# Altium schematic sheet PS_BANKS.SchDoc
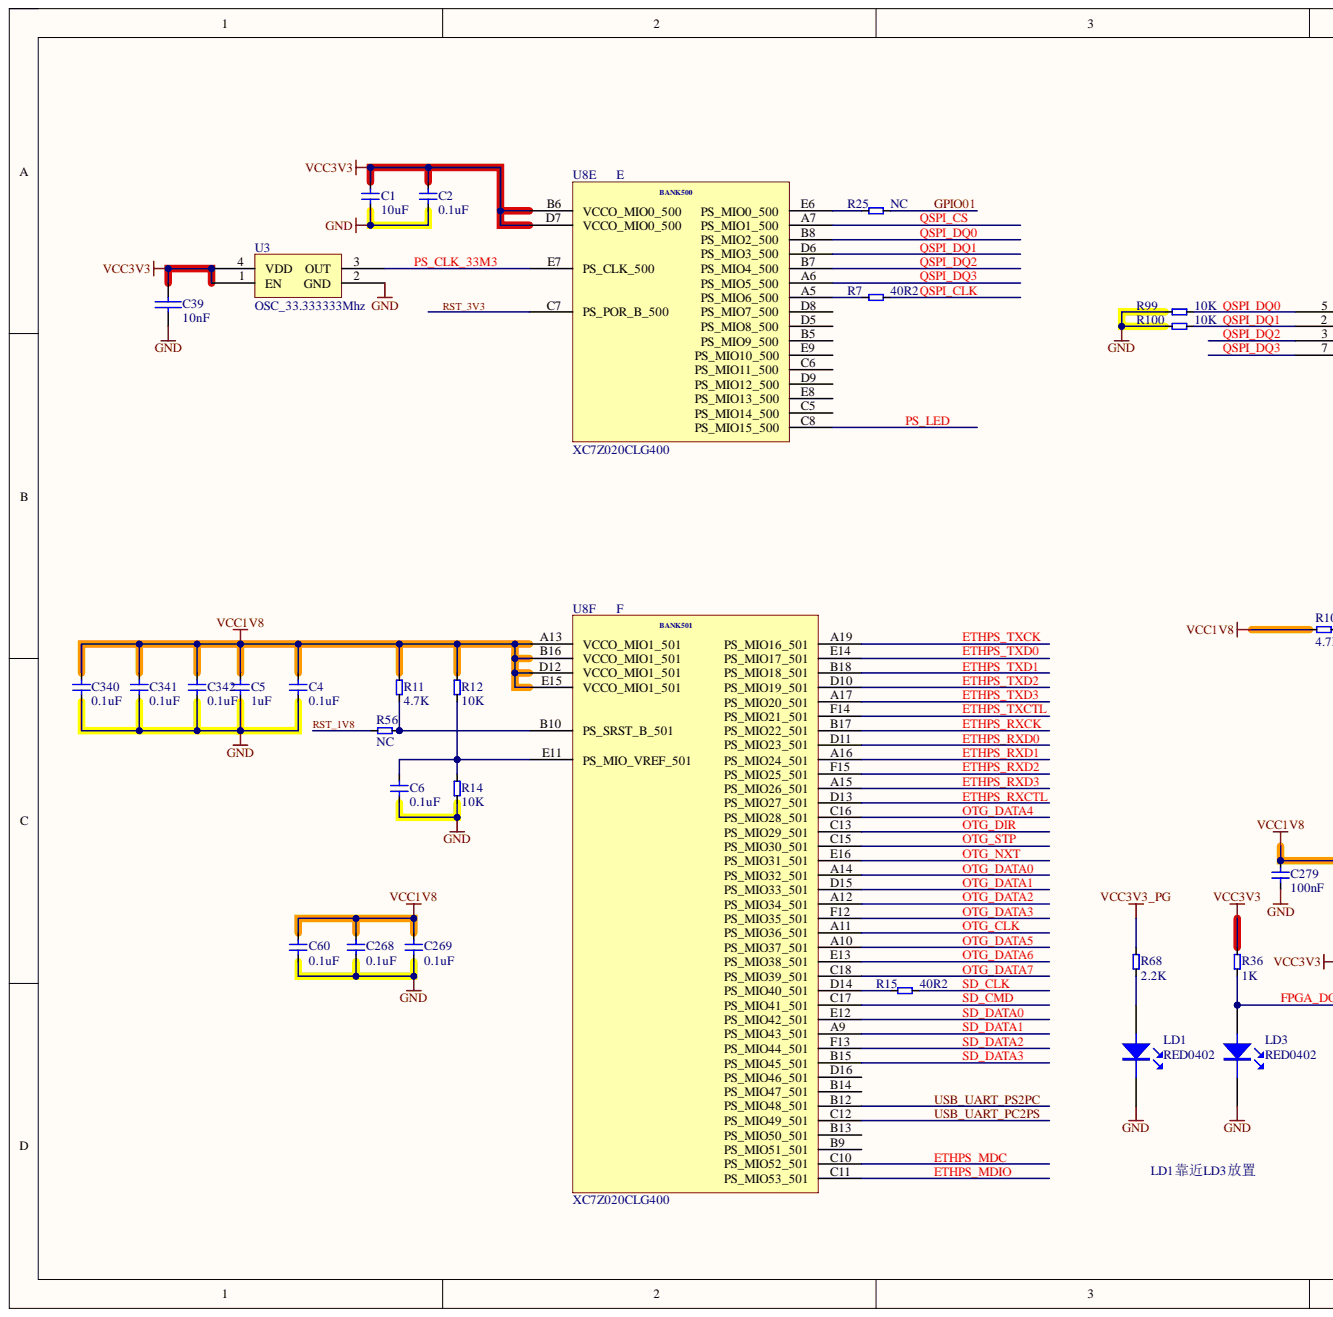
[diagram p0: PS_BANKS.SchDoc, left]
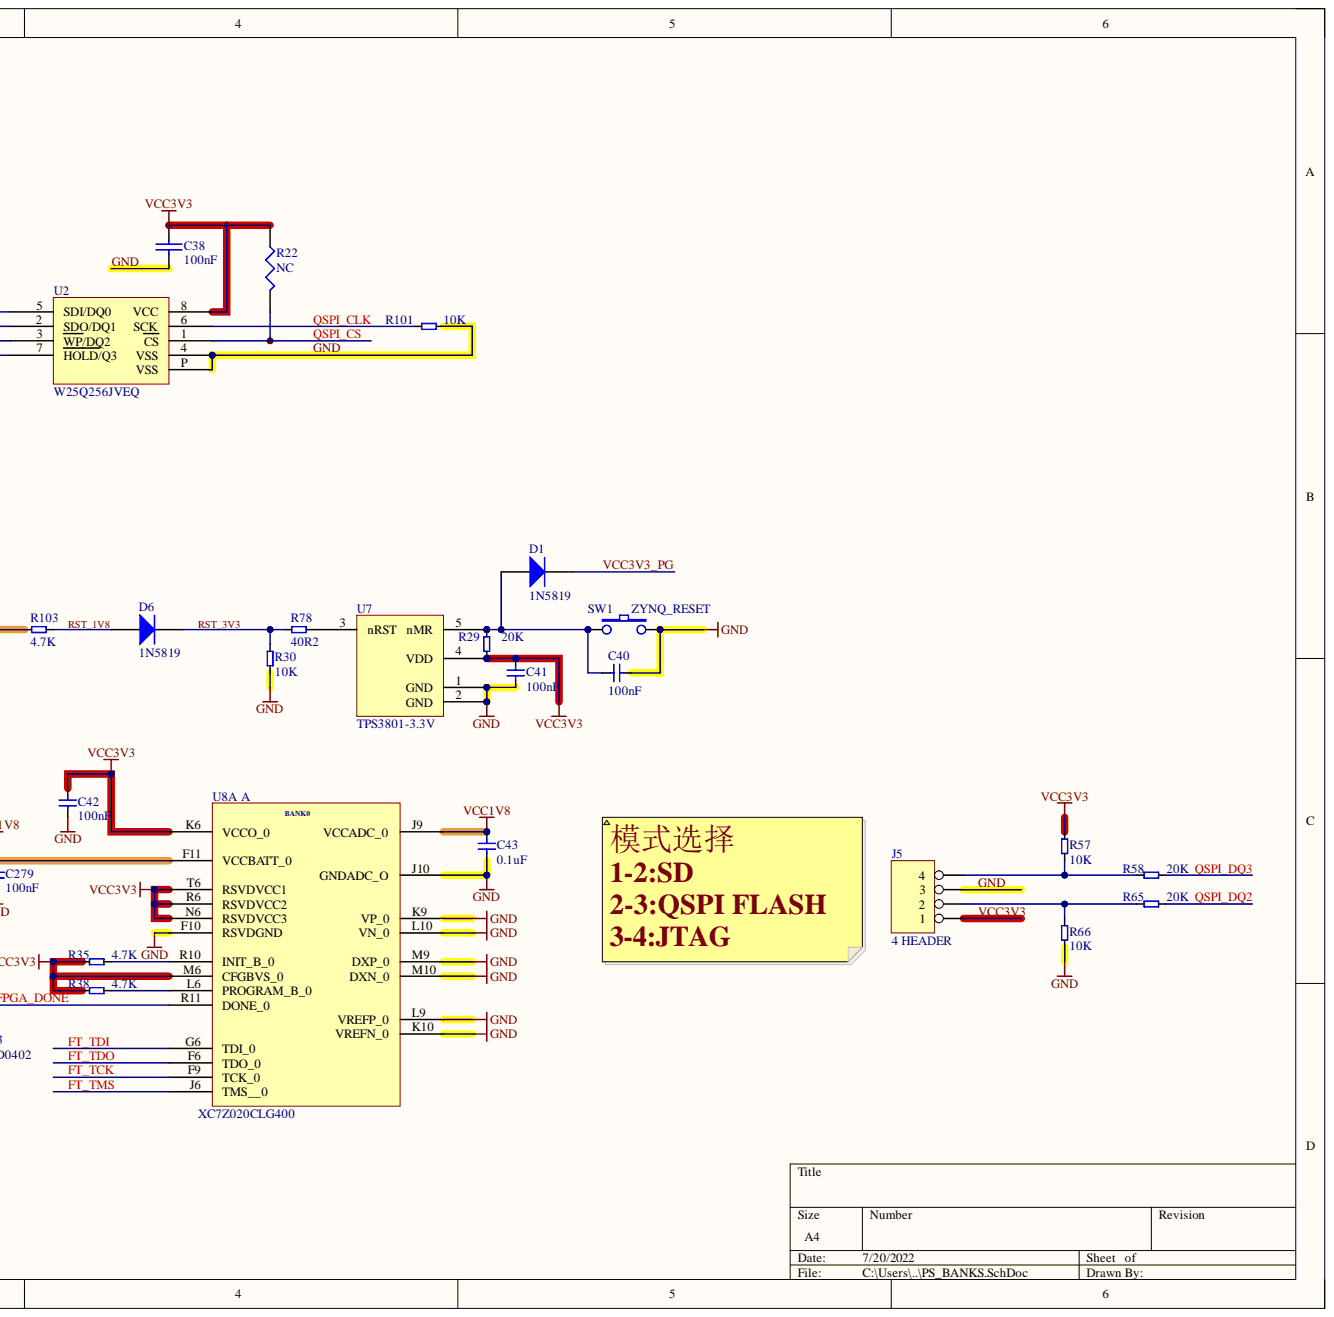
[diagram p1: PS_BANKS.SchDoc, right]

## Parts
C1  10uF  [C0603]
C2  0.1uF  [C0201]
C4  0.1uF  [C0201]
C5  1uF  [C0402]
C6  0.1uF  [C0201]
C38  100nF  [C0201]
C39  10nF  [CAP0402]
C40  100nF  [C0201]
C41  100nF  [C0201]
C42  100nF  [C0201]
C43  0.1uF  [C0201]
C60  0.1uF  [C0201]
C268  0.1uF  [C0201]
C269  0.1uF  [C0201]
C279  100nF  [C0201]
C340  0.1uF  [C0201]
C341  0.1uF  [C0201]
C342  0.1uF  [C0201]
D1  1N5819  [SOD-523_12]
D6  1N5819  [SOD-523_12]
J5  4 HEADER  [SIP4]
LD1  RED0402  [LED0402]
LD3  RED0402  [LED0402]
R7  40R2  [R0201]
R11  4.7K  [R0201]
R12  10K  [R0201]
R14  10K  [R0201]
R15  40R2  [R0201]
R22  NC  [R0201]
R25  NC  [R0201]
R29  20K  [R0201]
R30  10K  [R0201]
R35  4.7K  [R0201]
R36  1K  [R0201]
R38  4.7K  [R0201]
R56  NC  [R0201]
R57  10K  [R0201]
R58  20K  [R0201]
R65  20K  [R0201]
R66  10K  [R0201]
R68  2.2K  [R0201]
R78  40R2  [R0201]
R99  10K  [R0201]
R100  10K  [R0201]
R101  10K  [R0201]
R103  4.7K  [R0201]
SW1  ZYNQ_RESET  [EDGEKEY]
U2  W25Q256JVEQ  [MLP8]
U3  OSC_33.333333Mhz  [OSC_3225]
U7  TPS3801-3.3V  [SC-70-5]
U8  XC7Z020CLG400  [MY_CLG400]  (parts A,E,F)

## Nets
VCC1V8: C4.1 C5.1 C43.1 C60.2 C268.2 C269.2 C279.1 C340.1 C341.1 C342.1 R11.2 R12.2 R103.1 U8.A13(VCCO_MIO1_501) U8.B16(VCCO_MIO1_501) U8.D12(VCCO_MIO1_501) U8.E15(VCCO_MIO1_501) U8.F11(VCCBATT_0) U8.J9(VCCADC_0)
ETHPS_MDC: U8.C10(PS_MIO52_501)
ETHPS_MDIO: U8.C11(PS_MIO53_501)
ETHPS_RXCK: U8.B17(PS_MIO22_501)
ETHPS_RXCTL: U8.D13(PS_MIO27_501)
ETHPS_RXD0: U8.D11(PS_MIO23_501)
ETHPS_RXD1: U8.A16(PS_MIO24_501)
ETHPS_RXD2: U8.F15(PS_MIO25_501)
ETHPS_RXD3: U8.A15(PS_MIO26_501)
ETHPS_TXCK: U8.A19(PS_MIO16_501)
ETHPS_TXCTL: U8.F14(PS_MIO21_501)
ETHPS_TXD0: U8.E14(PS_MIO17_501)
ETHPS_TXD1: U8.B18(PS_MIO18_501)
ETHPS_TXD2: U8.D10(PS_MIO19_501)
ETHPS_TXD3: U8.A17(PS_MIO20_501)
FPGA_DONE: LD3.2 R36.1 U8.R11(DONE_0)
FT_TCK: U8.F9(TCK_0)
FT_TDI: U8.G6(TDI_0)
FT_TDO: U8.F6(TDO_0)
FT_TMS: U8.J6(TMS__0)
GND: C1.2 C2.2 C4.2 C5.2 C6.2 C38.2 C39.2 C40.2 C41.2 C42.2 C43.2 C60.1 C268.1 C269.1 C279.2 C340.2 C341.2 C342.2 J5.3 LD1.1 LD3.1 R14.1 R30.2 R66.1 R99.1 R100.1 R101.2 SW1.2 U2.4(VSS) U2.P(VSS) U3.2(GND) U7.1(GND) U7.2(GND) U8.F10(RSVDGND) U8.J10(GNDADC_O) U8.K9(VP_0) U8.K10(VREFN_0) U8.L9(VREFP_0) U8.L10(VN_0) U8.M9(DXP_0) U8.M10(DXN_0)
GPIO01: R25.2
OTG_CLK: U8.A11(PS_MIO36_501)
OTG_DATA0: U8.A14(PS_MIO32_501)
OTG_DATA1: U8.D15(PS_MIO33_501)
OTG_DATA2: U8.A12(PS_MIO34_501)
OTG_DATA3: U8.F12(PS_MIO35_501)
OTG_DATA4: U8.C16(PS_MIO28_501)
OTG_DATA5: U8.A10(PS_MIO37_501)
OTG_DATA6: U8.E13(PS_MIO38_501)
OTG_DATA7: U8.C18(PS_MIO39_501)
OTG_DIR: U8.C13(PS_MIO29_501)
OTG_NXT: U8.E16(PS_MIO31_501)
OTG_STP: U8.C15(PS_MIO30_501)
PS_CLK_33M3: U3.3(OUT) U8.E7(PS_CLK_500)
PS_LED: U8.C8(PS_MIO15_500)
QSPI_CLK: R7.2 R101.1 U2.6(SCK)
QSPI_CS: R22.2 U2.1(CS) U8.A7(PS_MIO1_500)
QSPI_DQ0: R99.2 U2.5(SDI/DQ0) U8.B8(PS_MIO2_500)
QSPI_DQ1: R100.2 U2.2(SDO/DQ1) U8.D6(PS_MIO3_500)
QSPI_DQ2: R65.2 U2.3(WP/DQ2) U8.B7(PS_MIO4_500)
QSPI_DQ3: R58.2 U2.7(HOLD/Q3) U8.A6(PS_MIO5_500)
RST_1V8: D6.1 R56.2 R103.2
RST_3V3: D6.2 R30.1 R78.1 U8.C7(PS_POR_B_500)
SD_CLK: R15.1
SD_CMD: U8.C17(PS_MIO41_501)
SD_DATA0: U8.E12(PS_MIO42_501)
SD_DATA1: U8.A9(PS_MIO43_501)
SD_DATA2: U8.F13(PS_MIO44_501)
SD_DATA3: U8.B15(PS_MIO45_501)
USB_UART_PC2PS: U8.C12(PS_MIO49_501)
USB_UART_PS2PC: U8.B12(PS_MIO48_501)
VCC3V3: C1.1 C2.1 C38.1 C39.1 C41.1 C42.1 J5.1 R22.1 R29.2 R35.1 R36.2 R38.1 R57.2 U2.8(VCC) U3.1(EN) U3.4(VDD) U7.4(VDD) U8.B6(VCCO_MIO0_500) U8.D7(VCCO_MIO0_500) U8.K6(VCCO_0) U8.M6(CFGBVS_0) U8.N6(RSVDVCC3) U8.R6(RSVDVCC2) U8.T6(RSVDVCC1)
VCC3V3_PG: D1.2 R68.2
NetC6_1: C6.1 R12.1 R14.2 U8.E11(PS_MIO_VREF_501)
NetC40_1: C40.1 D1.1 R29.1 SW1.1 U7.5(nMR)
NetJ5_2: J5.2 R65.1 R66.2
NetJ5_4: J5.4 R57.1 R58.1
NetLD1_2: LD1.2 R68.1
NetR7_1: R7.1 U8.A5(PS_MIO6_500)
NetR11_1: R11.1 R56.1 U8.B10(PS_SRST_B_501)
NetR15_2: R15.2 U8.D14(PS_MIO40_501)
NetR25_1: R25.1 U8.E6(PS_MIO0_500)
NetR35_2: R35.2 U8.R10(INIT_B_0)
NetR38_2: R38.2 U8.L6(PROGRAM_B_0)
NetR78_2: R78.2 U7.3(nRST)

Not connected: U8 B5(PS_MIO9_500) B9(PS_MIO51_501) B13(PS_MIO50_501) B14(PS_MIO47_501) C5(PS_MIO14_500) C6(PS_MIO11_500) D5(PS_MIO8_500) D8(PS_MIO7_500) D9(PS_MIO12_500) D16(PS_MIO46_501) E8(PS_MIO13_500) E9(PS_MIO10_500)

## Library data of the parts
C1 C2 C4-C6 C40-C43 C60 C268 C269 C279 C340-C342 = C: Capacitor; Component Kind: Standard; Library Name: 电容-SCH.LIB
C38 C39 = CAP: Capacitor; Component Kind: Standard
D1 D6 = SOD-123 B5819W  SL: Component Kind: Standard; ComponentLink1Description: 供应商链接; ComponentLink1URL: http://www.szlcsc.com/product/details_9093.html; Description: 1N5819; Library Name: Diode.SchLib; Package: SOD-123; Supplier: LC; SuppliersPartNumber: C8598
J5 = 4 HEADER: 4 Pin Header; Component Kind: Standard
LD1 LD3 = LED_12: Component Kind: Standard; Library Name: zc706_2_0.SCHLIB
R7 R11 R12 R14 R15 R25 R29 R30 R35 R36 R38 R56-R58 R65 R66 R68 R78 R99-R101 R103 = RES2: Component Kind: Standard; Library Name: 电阻-SCH.LIB
R22 = RES1: Component Kind: Standard
SW1 = SW PUSHBUTTON: Text Field1: 30; Text Field2: 20; Component Kind: Standard
U2 = S25FL256SAGNFI100: Component Kind: Standard; Library Name: antsdr.SCHLIB
U3 = 767-33.333333-12: 3.3V有源4P贴片晶振3225; Component Kind: Standard
U7 = TPS3801: Component Kind: Standard; Library Name: b200mini.SchLib
U8 = XC7Z020CLG400: Component Kind: Standard; Library Name: Schlib1.SchLib
